# Altium schematic sheet 10-Protections 2 of 2.SchDoc("10-Protections 2 of 2")
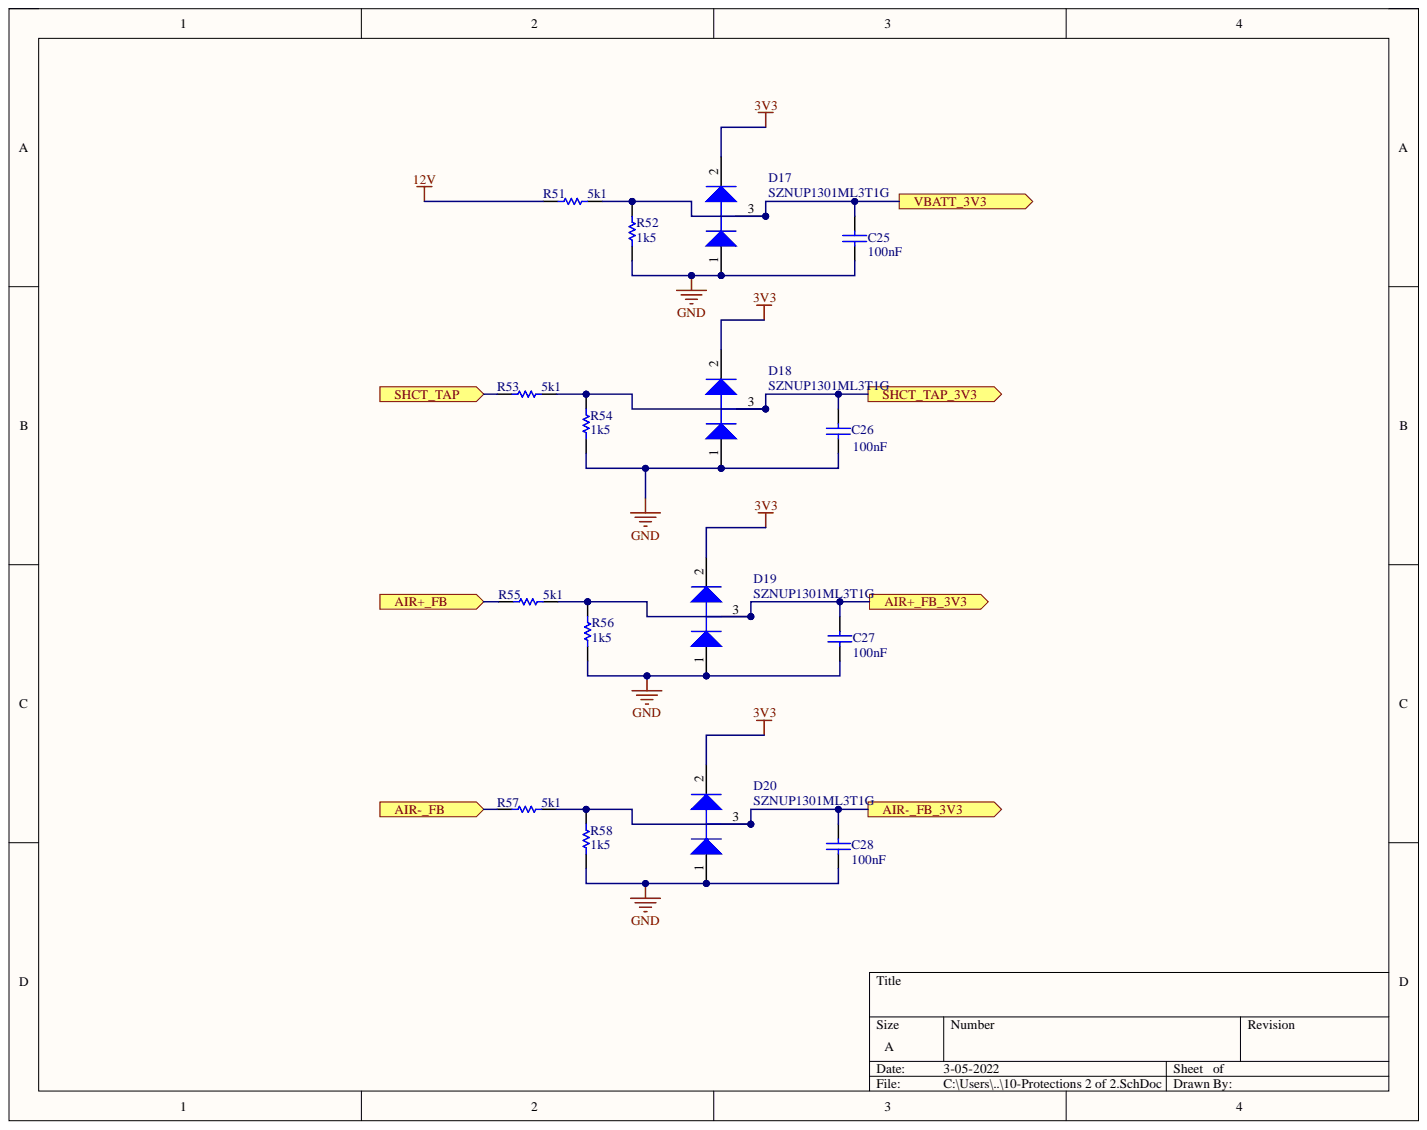
[diagram p0: 10-Protections 2 of 2.SchDoc]

## Parts
C25  value 100nF  [1608[0603]]  (hidden comment: Cap)
C26  value 100nF  [1608[0603]]  (hidden comment: Cap)
C27  value 100nF  [1608[0603]]  (hidden comment: Cap)
C28  value 100nF  [1608[0603]]  (hidden comment: Cap)
D17  SZNUP1301ML3T1G  [ONSC-SOT-23-3-318-08_V]
D18  SZNUP1301ML3T1G  [ONSC-SOT-23-3-318-08_V]
D19  SZNUP1301ML3T1G  [ONSC-SOT-23-3-318-08_V]
D20  SZNUP1301ML3T1G  [ONSC-SOT-23-3-318-08_V]
R51  value 5k1  [1608[0603]]  (hidden comment: Res1)
R52  value 1k5  [1608[0603]]  (hidden comment: Res1)
R53  value 5k1  [1608[0603]]  (hidden comment: Res1)
R54  value 1k5  [1608[0603]]  (hidden comment: Res1)
R55  value 5k1  [1608[0603]]  (hidden comment: Res1)
R56  value 1k5  [1608[0603]]  (hidden comment: Res1)
R57  value 5k1  [1608[0603]]  (hidden comment: Res1)
R58  value 1k5  [1608[0603]]  (hidden comment: Res1)

## Ports
AIR+_FB, AIR+_FB_3V3, AIR-_FB, AIR-_FB_3V3, SHCT_TAP, SHCT_TAP_3V3, VBATT_3V3

## Nets
3V3: D17.2 D18.2 D19.2 D20.2
12V: R51.1
GND: C25.1 C26.1 C27.1 C28.1 D17.1 D18.1 D19.1 D20.1 R52.1 R54.1 R56.1 R58.1
SHCT_TAP: R53.1 ; port SHCT_TAP
SHCT_TAP_3V3: C26.2 D18.3 R53.2 R54.2 ; port SHCT_TAP_3V3
VBATT_3V3: C25.2 D17.3 R51.2 R52.2 ; port VBATT_3V3
NetC27_2: C27.2 D19.3 R55.2 R56.2
NetC28_2: C28.2 D20.3 R57.2 R58.2
NetR55_1: R55.1
NetR57_1: R57.1

## Library data of the parts
C25-C28 = Cap: Capacitor; Manufacturer: Yageo; Manufacturer Part Number: CC0603KRX7R9BB104; Capacitance: 0.10µF; Temperature Coefficient: X7R; Tolerance: ±10%; Voltage - Rated: 50V; Applications: General Purpose; Category: Capacitors; Component Kind: Standard; ComponentLink1Description: http://www.yageo.com/documents/recent/UPY-GPHC_X7R_6.3V-to-50V_16.pdf; ComponentLink1URL: http://www.yageo.com/documents/recent/UPY-GPHC_X7R_6.3V-to-50V_16.pdf; Failure Rate: -; Features: -; Height - Seated (Max): -; Lead Spacing: -; Lead Style: -; Library Name: Miscellaneous Devices.IntLib; Mounting Type: Surface Mount, MLCC; Operating Temperature: -55°C ~ 125°C; Package / Case: 0603 (1608 Metric); Packaging: Cut Tape (CT); Part Status: Active; Pricing 1: 1=0.15, 10=0.043, 25=0.0312, 50=0.0232, 100=0.0189, 250=0.0158, 500=0.01346, 1000=0.01059 (CAD); Ratings: -; RoHS: RoHS Compliant; Signal Integrity: Cap; Simulation: CAP; Size / Dimension: 0.063" L x 0.031" W (1.60mm x 0.80mm); Stock 1: 4016262; Supplier 1: Digi-Key; Supplier Part Number 1: 311-1344-1-ND; Thickness (Max): 0.035" (0.90mm)
D17-D20 = CMP-1063-00171-1: Low Capacitance Diode Array for ESD Protection in a Single Data Line, 3-Pin SOT-23, Pb-Free, Tape and Reel; Manufacturer: On Semiconductor; C Max (pF): 0.9; Direction: Bidirectional; Number of Lines: 1; V(BR) Min (V): 70; Component Kind: Standard; ComponentLink1Description: Manufacturer URL; ComponentLink1URL: http://www.onsemi.com/; ComponentLink2Description: Datasheet; ComponentLink2URL: http://www.onsemi.cn/pub_link/Collateral/NUP1301ML3T1-D.PDF; ComponentLink3Description: Package Specification; ComponentLink3URL: http://www.onsemi.cn/pub_link/Collateral/318-08.PDF; DatasheetVersion: Rev. 6; Library Name: Altium Content Vault; Mounting Technology: Surface Mount; PackageDescription: 3-Pin Small Outline Transistor, Body 2.92 x 1.3 mm, Pitch 0.95 mm; PackageReference: SOT-23-3-318-08; PackageVersion: Rev. AP, 11/2009; Packing: Tape and Reel; PartNumber: SZNUP1301ML3T1G; RoHS: Pb-Free; VRWM Max (V): 70
R51-R58 = Res1: Resistor; Manufacturer: Yageo; Manufacturer Part Number: RC0603JR-075K1L; Power (Watts): 0.1W, 1/10W; Resistance (Ohms): 5.1k; Temperature Coefficient: ±100ppm/°C; Tolerance: ±5%; Category: Resistors; Component Kind: Standard; ComponentLink1Description: http://www.yageo.com/NewPortal/yageodocoutput?fileName=/pdf/R-Chip/PYu-RC_Group_51_RoHS_L_4.pdf; ComponentLink1URL: http://www.yageo.com/NewPortal/yageodocoutput?fileName=/pdf/R-Chip/PYu-RC_Group_51_RoHS_L_4.pdf; Composition: Thick Film; Failure Rate: -; Features: Moisture Resistant; Height - Seated (Max): 0.022" (0.55mm); Library Name: Miscellaneous Devices.IntLib; Number of Terminations: 2; Operating Temperature: -55°C ~ 155°C; Package / Case: 0603 (1608 Metric); Packaging: Cut Tape (CT); Part Status: Active; RoHS: RoHS Compliant; Signal Integrity: Res; Simulation: RESISTOR; Size / Dimension: 0.063" L x 0.031" W (1.60mm x 0.80mm); Supplier 1: Digi-Key; Supplier Device Package: 0603
  R51 R53 R55 R57: Pricing 1: 1=0.16, 10=0.016, 25=0.0112, 50=0.0084, 100=0.0062, 250=0.0048, 500=0.00382, 1000=0.00281 (CAD); Stock 1: 782184; Supplier Part Number 1: 311-5.1KGRCT-ND
  R52 R54 R56 R58: ComponentLink2Description: http://www.vishay.com/docs/20065/rcse3.pdf; ComponentLink2URL: http://www.vishay.com/docs/20065/rcse3.pdf; Pricing 1: 1=0.21, 10=0.192, 25=0.1332, 50=0.1038, 100=0.0741, 250=0.05776, 500=0.04586, 1000=0.03257 (CAD); Stock 1: 14165; Supplier Part Number 1: 541-2789-1-ND
